# Altium schematic sheet Power.SchDoc
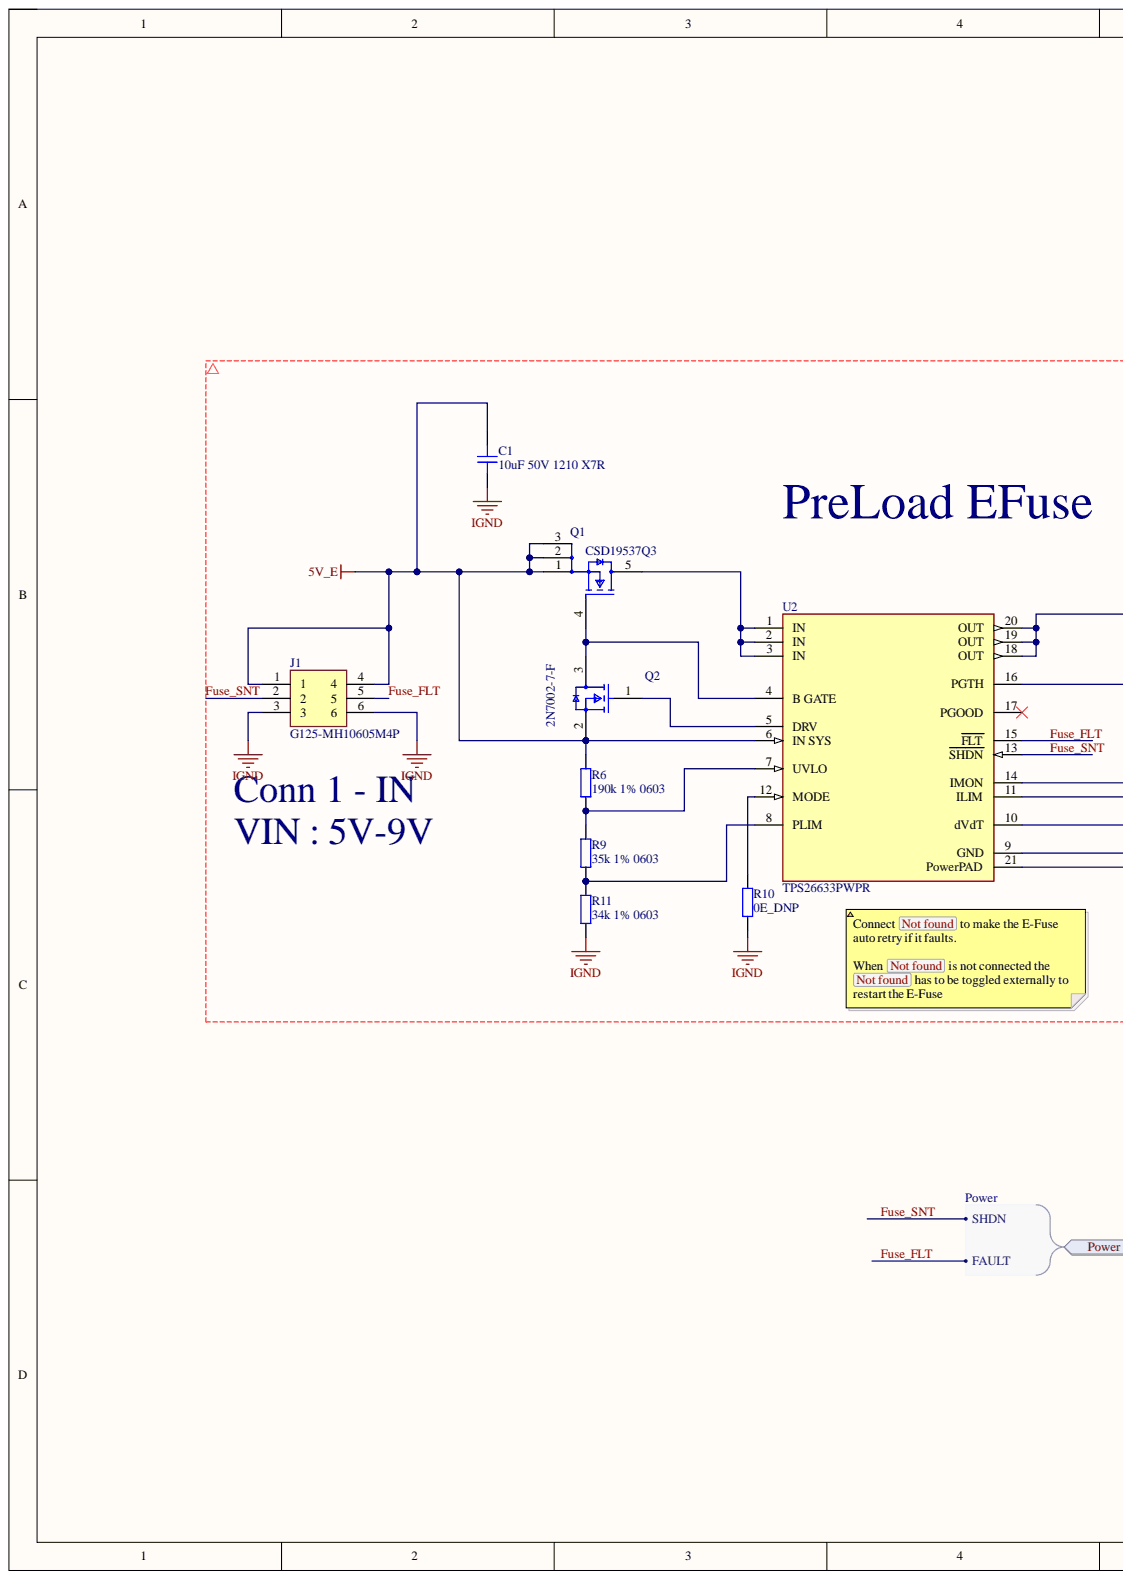
[diagram p0: Power.SchDoc, left]
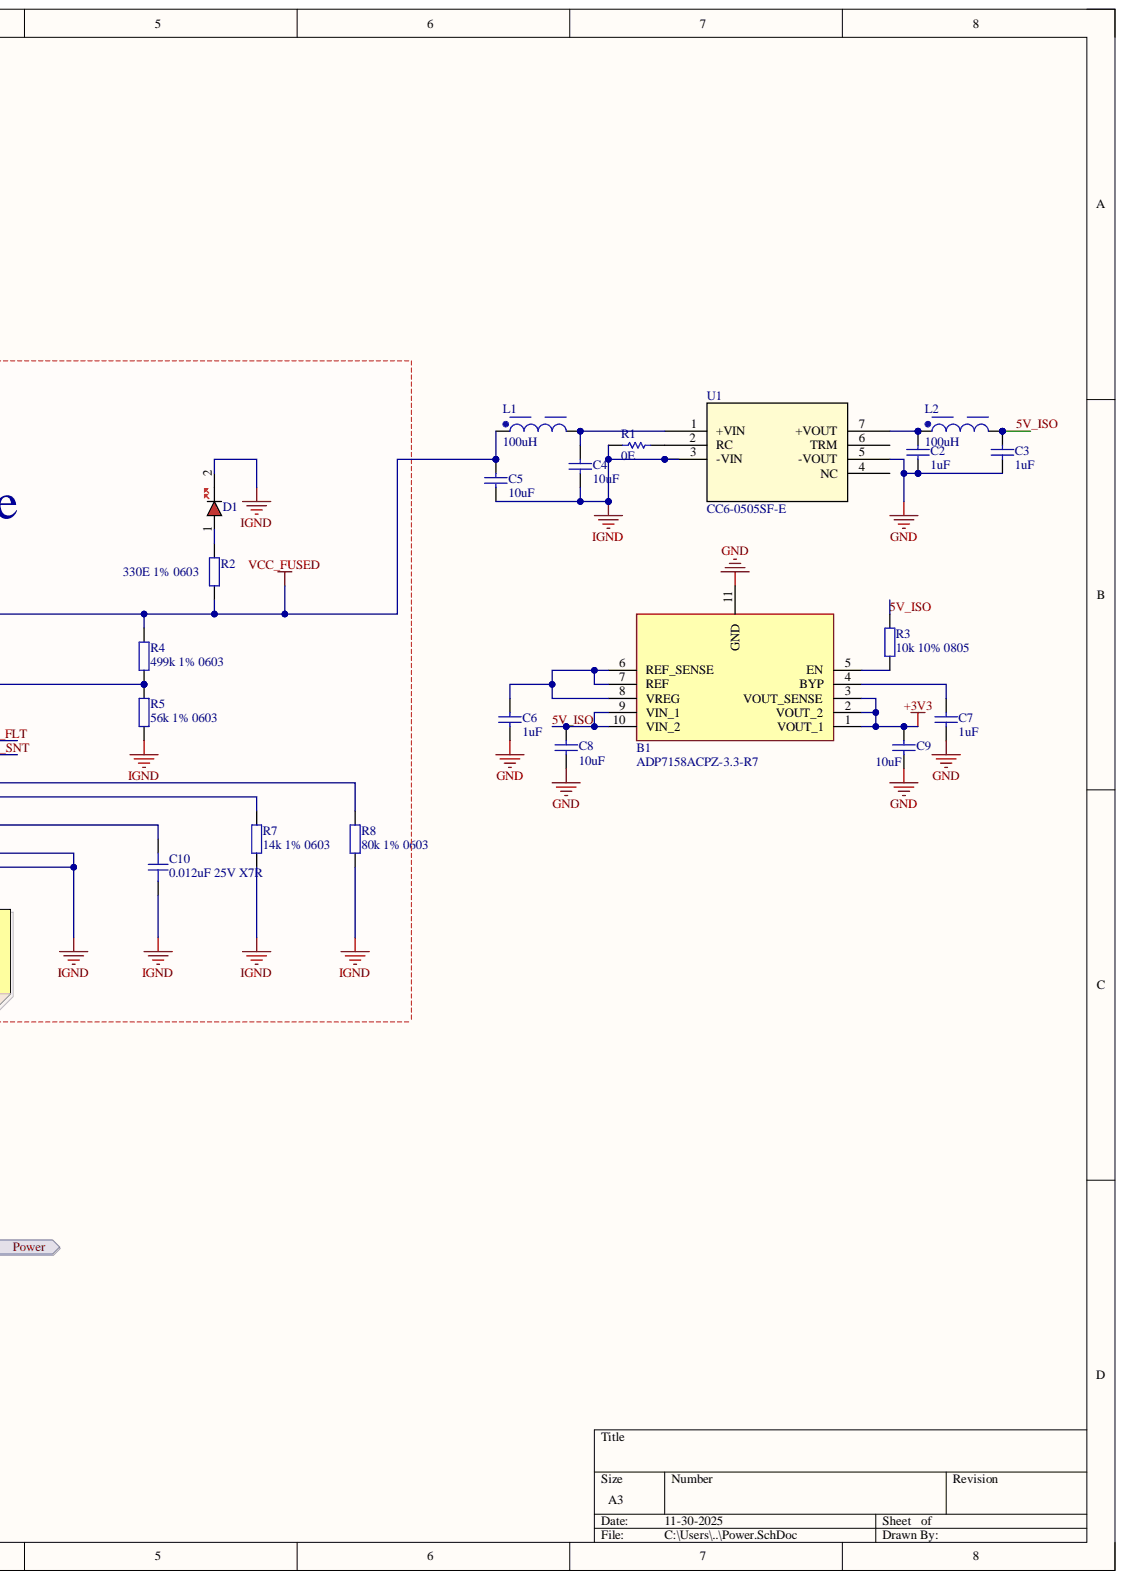
[diagram p1: Power.SchDoc, right]

## Parts
B1  ADP7158ACPZ-3.3-R7  [LFCSP -10]
C1  10uF 50V 1210 X7R  [CAP1210S]
C2  1uF  [CAP0603S]
C3  1uF  [CAP0603S]
C4  10uF  [CAP0603S]
C5  10uF  [CAP0603S]
C6  1uF  [CAP0603S]
C7  1uF  [CAP0603S]
C8  10uF  [CAP0603S]
C9  10uF  [CAP0603S]
C10  0.012uF 25V X7R  [CAP0805S]
D1  RED  [LED 0603_RED]  (value not drawn)
J1  G125-MH10605M4P  [HARWIN_G125-MH10605M4P]
L1  100uH  [IND_SEMI_SHIELD_6.0X6.0_H4.5_SMD]
L2  100uH  [IND_SEMI_SHIELD_6.0X6.0_H4.5_SMD]
Q1  CSD19537Q3  [TRANS_CSD19537Q3]
Q2  2N7002-7-F  [SOT23_3]
R1  0E  [RES0402S]
R2  330E 1% 0603  [RES0603S]
R3  10k 10% 0805  [RES0805S]
R4  499k 1% 0603  [RES0603S]
R5  56k 1% 0603  [RES0603S]
R6  190k 1% 0603  [RES0603S]
R7  14k 1% 0603  [RES0603S]
R8  80k 1% 0603  [RES0402S]
R9  35k 1% 0603  [RES0603S]
R10  0E_DNP  [RES0603S]
R11  34k 1% 0603  [RES0603S]
U1  CC6-0505SF-E  [CONV_CC6-0505SF-E]
U2  TPS26633PWPR  [SOP65P640X110-21N]

## Ports
Power, Power.FAULT, Power.SHDN

## Nets
5V_E: C1.2 J1.1 J1.4 Q1.1 Q1.2 Q1.3 Q2.2 R6.2 U2.6(IN_SYS)
+3V3: B1.1(VOUT_1) B1.2(VOUT_2) B1.3(VOUT_SENSE) C9.1
GND: B1.11(GND) C2.2 C3.2 C6.2 C7.2 C8.2 C9.2 U1.5(-VOUT)
IGND: C1.1 C4.2 C5.2 C10.1 D1.2 J1.3 J1.6 R1.1 R5.1 R7.1 R8.1 R10.1 R11.1 U1.3(-VIN) U2.9(GND) U2.21(PowerPAD)
VCC_FUSED: C5.1 L1.1 R2.1 R4.2 U2.18(OUT) U2.19(OUT) U2.20(OUT)
5V_ISO: B1.9(VIN_1) B1.10(VIN_2) C3.1 C8.1 L2.2 R3.1
Fuse_FLT: J1.5 U2.15(FLT) ; port Power
Fuse_SNT: J1.2 U2.13(SHDN) ; port Power
NetB1_4: B1.4(BYP) C7.1
NetB1_5: B1.5(EN) R3.2
NetB1_6: B1.6(REF_SENSE) B1.7(REF) B1.8(VREG) C6.1
NetC2_1: C2.1 L2.1 U1.7(+VOUT)
NetC4_1: C4.1 L1.2 U1.1(+VIN)
NetC10_2: C10.2 U2.10(dVdT)
NetD1_1: D1.1 R2.2
NetQ1_4: Q1.4 Q2.3 U2.4(B_GATE)
NetQ1_5: Q1.5 U2.1(IN) U2.2(IN) U2.3(IN)
NetQ2_1: Q2.1 U2.5(DRV)
NetR1_2: R1.2 U1.2(RC)
NetR4_1: R4.1 R5.2 U2.16(PGTH)
NetR6_1: R6.1 R9.2 U2.7(UVLO)
NetR7_2: R7.2 U2.11(ILIM)
NetR8_2: R8.2 U2.14(IMON)
NetR9_1: R9.1 R11.2 U2.8(PLIM)
NetR10_2: R10.2 U2.12(MODE)

Not connected: U1 4(NC) 6(TRM); U2 17(PGOOD)

## Library data of the parts
B1 = ADP7158ACPZ-3.3-R7: LDO Voltage Regulators 3.3/5Vin 2A Ultra Low Noise LDO Fixed; Manufacturer_Name: Analog Devices; Geometry.Height: 0.85mm; Component Kind: Standard; Datasheet Link: http://www.analog.com/media/en/technical-documentation/data-sheets/ADP7158.pdf; Library Name: ADP7158ACPZ-3.3-R7.SchLib; Manufacturer_Part_Number: ADP7158ACPZ-3.3-R7; Mouser Part Number: 584-ADP7158ACPZ3.3R7; Mouser Price/Stock: https://www.mouser.co.uk/ProductDetail/Analog-Devices/ADP7158ACPZ-3.3-R7?qs=JeIcUl65ClCPnjVOMj%2F7AA%3D%3D
C1 = CAP_1210: Capacitor SMD 1210; Component Kind: Standard; Library Name: Schematic-Lib-PhilsLab.SchLib
C2-C9 = CAP: Capacitor; Value: 100pF; Component Kind: Standard; Library Name: Miscellaneous Devices.IntLib; Published: 8-Jun-2000; Revision: July-2002: Re-released for DXP Platform.; Signal Integrity: Cap; Simulation: CAP; Publisher: Altium Limited
C10 = CAP_0603: Capacitor SMD 0603; Component Kind: Standard; Library Name: Schematic-Lib-PhilsLab.SchLib
D1 = LED_0603_RED: LED 0603 RED SMD; Component Kind: Standard; Library Name: Schematic-Lib-PhilsLab.SchLib
J1 = G125-MH10605M4P: Connector; Manufacturer_Name: Harwin; Component Kind: Standard; Datasheet Link: https://content.harwin.com/m/667f1be9427fb768/original/DRG-02921-Technical-Drawing-Datasheet-G125-MH1XX05M4P-pdf.pdf; Height: 5.25mm; Library Name: SamacSys.SchLib; Manufacturer_Part_Number: G125-MH10605M4P; Mouser Part Number: 855-G125MH1065M4PM4P; Mouser Price/Stock: https://www.mouser.co.uk/ProductDetail/Harwin/G125-MH10605M4P?qs=vHuUswq2%252BswF07IXFR1W6A%3D%3D
L1 L2 = CMP-1396-00036-2: NexarPartId: 22866940; Core Material: Ferrite; Current Rating: 1.5A; DC Resistance (DCR): 165mΩ; Inductance: 100µH; Inductance Tolerance: 20%; Resistance: 198mΩ; Saturation Current: 1.8A; Self Resonant Frequency: 5.9MHz; Shielding: Shielded; Test Frequency: 100kHz; Ambient Temperature Range High: 110°C; Ambient Temperature Range Low: -40°C; Case/Package: Nonstandard; Component Kind: Standard; Composition: Wirewound; Depth: 10mm; Height: 5mm; Height - Seated (Max): 5.0038mm; Length: 10mm; Max DC Current: 1.5A; Max Operating Temperature: 150°C; Min Operating Temperature: -40°C; Mount: Surface Mount; Number of Pins: 2; Packaging: Digi-Reel®; REACH SVHC: Unknown; RMS Current (Irms): 1.5A; RoHS: Compliant; Series Resistance: 198mΩ; Termination: SMD/SMT; Tolerance: 20%; Width: 10mm; Library Name: TMTC_Board.SCHLIB
Q1 = 7382af88e928fdc71488234cfaaf11c: MOSFET N-CH 100V 50A 8VSON; Fall Time: 3ns; Gate to Source Voltage (Vgs): 20V; Input Capacitance: 1.68nF; Max Power Dissipation: 2.8W; Rds On Max: 14.5mR; Turn-Off Delay Time: 10ns; Turn-On Delay Time: 5ns; Component Kind: Standard; Contact Plating: Copper; Continuous Drain Current (ID): 50A; Drain to Source Voltage (Vdss): 100V; Lead Free: Contains Lead; Library Name: Miscellaneous Devices.IntLib; Max Operating Temperature: 150°C; Min Operating Temperature: -55°C; Mount: Surface Mount; Number of Pins: 8; Packaging: Rail/Tube; Rise Time: 3ns; RoHS Compliant: Yes
Q2 = 3b9c635fedb024a5347a57b145af9cc: MOSFET N-CH 60V 115MA SOT23-3; Drain to Source Breakdown Voltage: 70V; Drain to Source Resistance: 7.5R; Element Configuration: Single; Fall Time: 5.6ns; Gate to Source Voltage (Vgs): 20V; Input Capacitance: 50pF; Max Power Dissipation: 300mW; Nominal Vgs: 2.5V; Number of Channels: 1; Power Dissipation: 540mW; Rise Time: 3ns; Turn-Off Delay Time: 11ns; Turn-On Delay Time: 7ns; Case/Package: SOT-23; Component Kind: Standard; Contact Plating: Tin; Continuous Drain Current (ID): 115mA; Current Rating: 115mA; Drain to Source Voltage (Vdss): 60V; Dual Supply Voltage: 60V; Height: 1mm; Lead Free: Lead Free; Length: 2.9mm; Library Name: Miscellaneous Devices.IntLib; Max Operating Temperature: 150°C; Min Operating Temperature: -55°C; Mount: Surface Mount; Number of Elements: 1; Number of Pins: 3; On-State Resistance: 7.5R; Package Quantity: 3000; Packaging: Tape and Reel; Radiation Hardening: No; Rds On Max: 7.5R; REACH SVHC: No SVHC; Termination: SMD/SMT; Threshold Voltage: 2.5V; Voltage: 60V; Voltage Rating (DC): 60V; Weight: 0.000282oz; Width: 1.3mm
R1 = res1: Resistor; Value: 1K; Component Kind: Standard; Library Name: Miscellaneous Devices.IntLib; Published: 8-Jun-2000; Revision: July-2002: Re-released for DXP Platform.; Signal Integrity: Res; Simulation: RESISTOR; Publisher: Altium Limited
R2 R4-R11 = RES_0603: Resistor SMD 0603; Component Kind: Standard; Library Name: Schematic-Lib-PhilsLab.SchLib
R3 = RES_0805: Resistor SMD 0805; Component Kind: Standard; Library Name: Schematic-Lib-PhilsLab.SchLib
U1 = CC6-0505SF-E: No Description Available; Mfr_Name: TDK; Component Kind: Standard; Library Name: CC6-0505SF-E.SchLib; RefDes: RefDes; Type: Power Supplies Board Mount
U2 = 3aa6c8470eea581a3a5f71473fd1f1f: 4.5V - 60V 6A 29MOHM EFUSE; Component Kind: Standard; Library Name: Miscellaneous Devices.IntLib
